# Altium schematic sheet Main.SchDoc
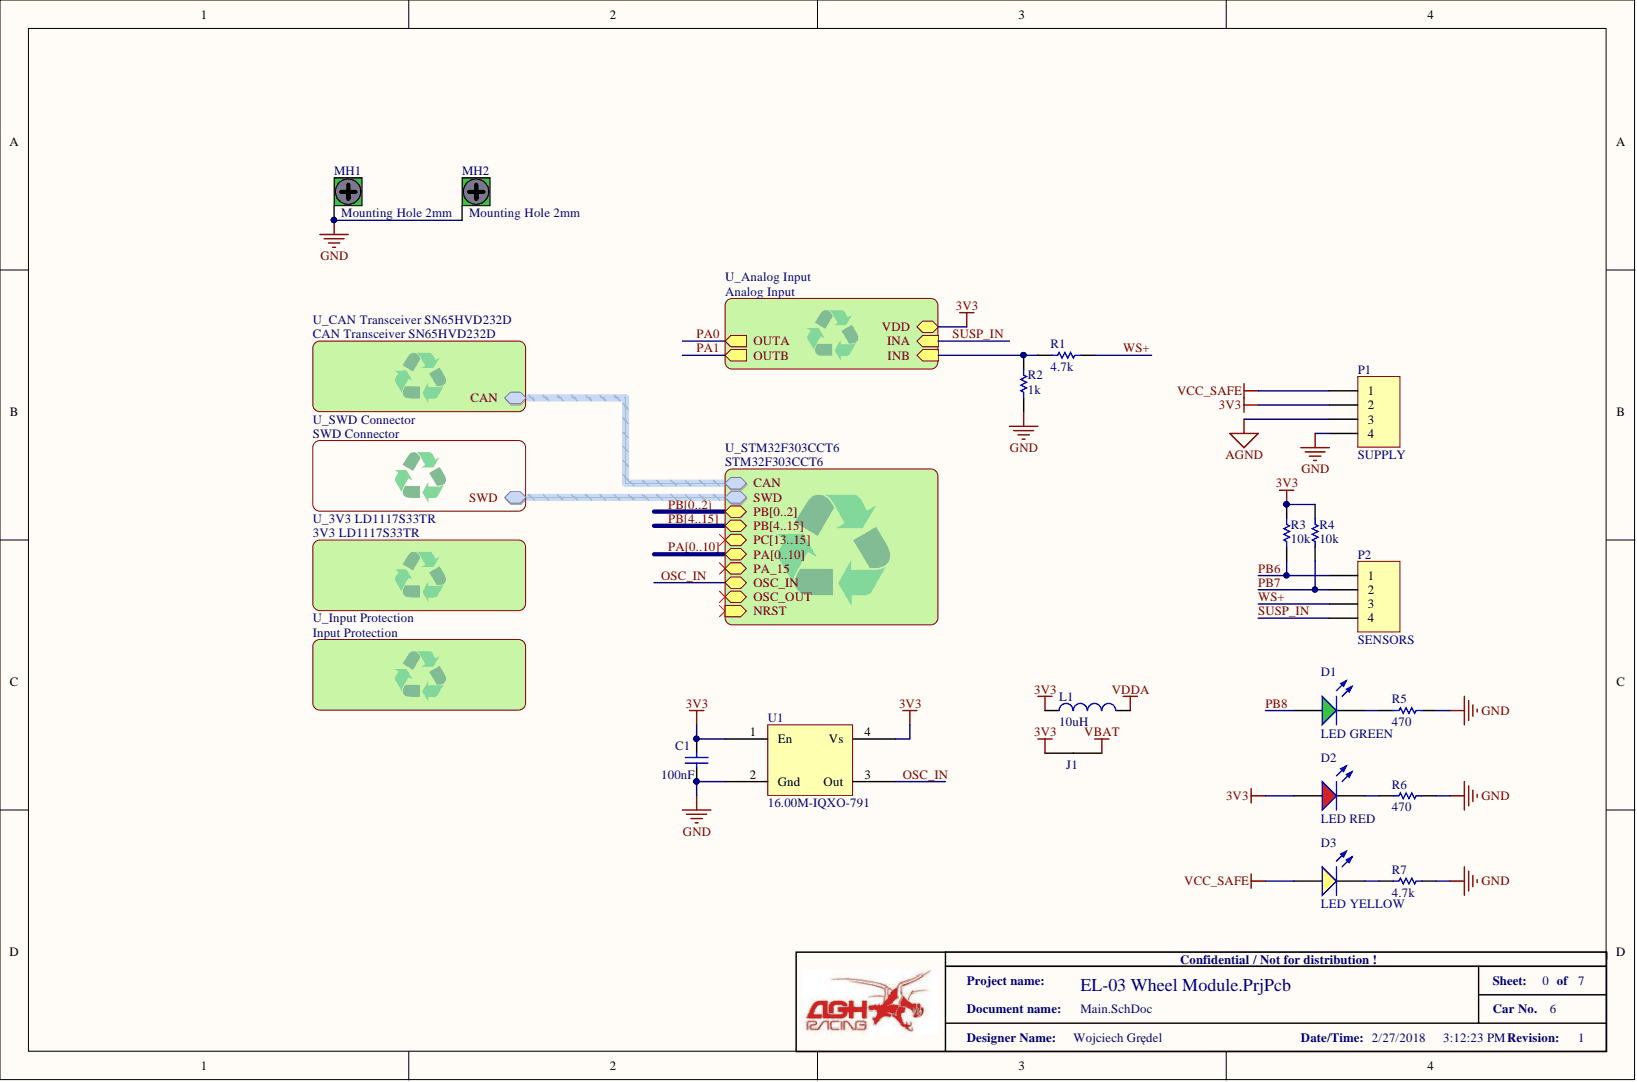
[diagram p0: Main.SchDoc]

## Parts
C1  value 100nF  [CAP_0603_IPC_MD]  (hidden comment: Cap)
D1  LED GREEN  [LED_0603_GREEN_IPC_MD]
D2  LED RED  [LED_0603_RED_IPC_MD]
D3  LED YELLOW  [LED_0603_YELLOW_IPC_MD]
J1  NetTie  [NETTIE]  (value not drawn)
L1  value 10uH  [IND_0603_IPC_HD]  (hidden comment: Ind)
MH1  Mounting Hole 2mm  [MOUNTING HOLE 2mm]  (no BOM)
MH2  Mounting Hole 2mm  [MOUNTING HOLE 2mm]  (no BOM)
P1  SUPPLY  [WIRE_PADS_4]
P2  SENSORS  [WIRE_PADS_4]
R1  value 4.7k  [RES_0603_IPC_HD]  (hidden comment: Res)
R2  value 1k  [RES_0603_IPC_HD]  (hidden comment: Res)
R3  value 10k  [RES_0603_IPC_HD]  (hidden comment: Res)
R4  value 10k  [RES_0603_IPC_HD]  (hidden comment: Res)
R5  value 470  [RES_0603_IPC_MD]  (hidden comment: Res)
R6  value 470  [RES_0603_IPC_MD]  (hidden comment: Res)
R7  value 4.7k  [RES_0603_IPC_MD]  (hidden comment: Res)
U1  16.00M-IQXO-791  [ICLCX18]

## Nets
3V3: C1.2 D2.1 J1.1 L1.1 P1.2 R3.2 R4.2 U1.1(En) U1.4(Vs)
AGND: P1.3
GND: C1.1 MH1.MOUNTING MH2.MOUNTING P1.4 R2.1 R5.1 R6.1 R7.1 U1.2(Gnd)
VBAT: J1.2
VCC_SAFE: D3.1 P1.1
VDDA: L1.2
OSC_IN: U1.3(Out)
PB6: P2.1 R3.1  [also labelled PB]
PB7: P2.2 R4.1  [also labelled PB]
PB8: D1.1  [also labelled PB]
SUSP_IN: P2.4
WS+: P2.3 R1.1
NetD1_2: D1.2 R5.2
NetD2_2: D2.2 R6.2
NetD3_2: D3.2 R7.2
NetR1_2: R1.2 R2.2
CAN.CAN_RX:
CAN.CAN_TX:
NRST:
OSC_OUT:
PA0:  [also labelled PA]
PA1:  [also labelled PA]
PA2:  [also labelled PA]
PA3:  [also labelled PA]
PA4:  [also labelled PA]
PA5:  [also labelled PA]
PA6:  [also labelled PA]
PA7:  [also labelled PA]
PA8:  [also labelled PA]
PA9:  [also labelled PA]
PA10:  [also labelled PA]
PA_15:
PB0:  [also labelled PB]
PB1:  [also labelled PB]
PB2:  [also labelled PB]
PB4:  [also labelled PB]
PB5:  [also labelled PB]
PB9:  [also labelled PB]
PB10:  [also labelled PB]
PB11:  [also labelled PB]
PB12:  [also labelled PB]
PB13:  [also labelled PB]
PB14:  [also labelled PB]
PB15:  [also labelled PB]
SWD.NRST:
SWD.SWCLK:
SWD.SWDIO:
SWD.SWO:
SWD.VDD_TARGET:

## Library data of the parts
C1 = Cap: Capacitor; Component Kind: Standard; Library Name: agh_racing_2018.SchLib
L1 = Ind: Inductor; Component Kind: Standard; Library Name: agh_racing_2018.SchLib
MH1 MH2 = Mounting Hole: Library Name: agh_racing_2018.SchLib
P1 P2 = Header 4: Header, 4-Pin; Component Kind: Standard; Library Name: agh_racing_2018.SchLib
R1-R7 = Res: Resistor; Component Kind: Standard; Library Name: agh_racing_2018.SchLib
U1 = 16.00M-IQXO-791: Crystal Clock Oscillator; Manufacturer: IQD FREQUENCY PRODUCTS; MPN: LFSPXO066657CUTT; Component Kind: Standard; Name: 16.00M-IQXO-791; SPN: 16.00M-IQXO-791; Supplier: TME; Library Name: agh_racing_2018_temp.SchLib
D1 = LED GREEN: Component Kind: Standard; Library Name: agh_racing_2018.SchLib
D2 = LED RED: Component Kind: Standard; Library Name: agh_racing_2018.SchLib
D3 = LED YELLOW: Component Kind: Standard; Library Name: agh_racing_2018.SchLib
J1 = NetTie: Component Kind: Net Tie; Library Name: agh_racing_2018.SchLib
